# Altium schematic sheet SH4 - MICROCONTROLLER.SchDoc
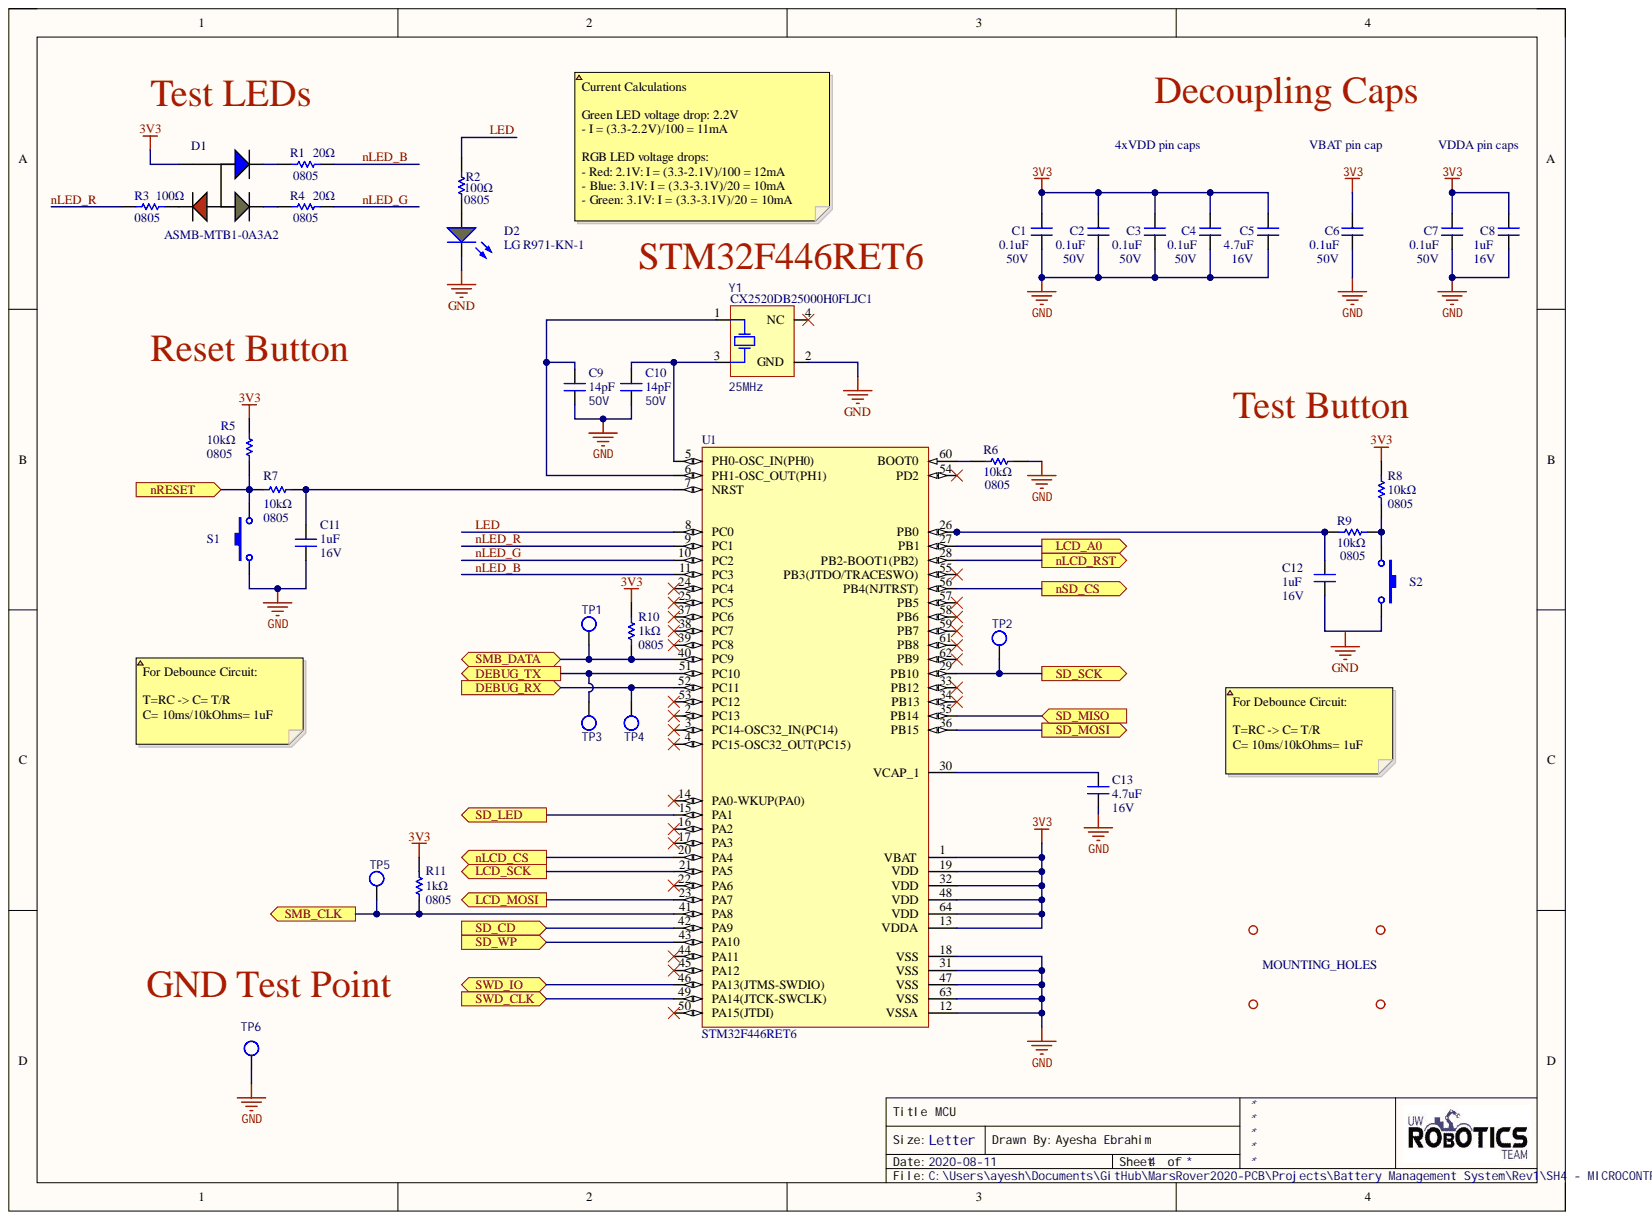
[diagram p0: SH4 - MICROCONTROLLER.SchDoc]

## Parts
C1  value 0.1uF  Voltage Rating: 50V  [CAPC2012X140N]  (hidden comment: CAP_0.1uF_50V_0805)
C2  value 0.1uF  Voltage Rating: 50V  [CAPC2012X140N]  (hidden comment: CAP_0.1uF_50V_0805)
C3  value 0.1uF  Voltage Rating: 50V  [CAPC2012X140N]  (hidden comment: CAP_0.1uF_50V_0805)
C4  value 0.1uF  Voltage Rating: 50V  [CAPC2012X140N]  (hidden comment: CAP_0.1uF_50V_0805)
C5  value 4.7uF  Capacitance: 4.7uF  Voltage Rating: 16V  [CAPC2012X140N]  (hidden comment: CAP_4.7uF_16V_0805)
C6  value 0.1uF  Voltage Rating: 50V  [CAPC2012X140N]  (hidden comment: CAP_0.1uF_50V_0805)
C7  value 0.1uF  Voltage Rating: 50V  [CAPC2012X140N]  (hidden comment: CAP_0.1uF_50V_0805)
C8  value 1uF  Capacitance: 1uF  Voltage Rating: 16V  [CAPC2012X140N]  (hidden comment: CAP_1uF_16V_0805)
C9  value 14pF  Capacitance: 14pF  Voltage Rating: 50V  [CAPC0603(1608)55_N]  (hidden comment: CL10C140JB8NNNC)
C10  value 14pF  Capacitance: 14pF  Voltage Rating: 50V  [CAPC0603(1608)55_N]  (hidden comment: CL10C140JB8NNNC)
C11  value 1uF  Capacitance: 1uF  Case Code (Imperial): 0805  Voltage Rating: 16V  [CAPC2012X140N]  (hidden comment: CAP_1uF_16V_0805)
C12  value 1uF  Capacitance: 1uF  Case Code (Imperial): 0805  Voltage Rating: 16V  [CAPC2012X140N]  (hidden comment: CAP_1uF_16V_0805)
C13  value 4.7uF  Capacitance: 4.7uF  Voltage Rating: 16V  [CAPC2012X140N]  (hidden comment: CAP_4.7uF_16V_0805)
D1  Manufacturer Part Number 1: ASMB-MTB1-0A3A2  [LED_RGB]  (hidden comment: LED_RGB)
D2  DIO_LED_G  [LED_0805_LINE]  (value not drawn)
MH1  MOUNTING_HOLES  [MOUNTING_HOLE]
MH2  MOUNTING_HOLES  [MOUNTING_HOLE]
MH3  MOUNTING_HOLES  [MOUNTING_HOLE]
MH4  MOUNTING_HOLES  [MOUNTING_HOLE]
R1  value 20Ω  Case Code (Imperial): 0805  [RESC2012X60N]  (hidden comment: RES_20Ω_0805)
R2  value 100Ω  Case Code (Imperial): 0805  [RESC2012X60N]  (hidden comment: RES_100Ω_0805)
R3  value 100Ω  Case Code (Imperial): 0805  [RESC2012X60N]  (hidden comment: RES_100Ω_0805)
R4  value 20Ω  Case Code (Imperial): 0805  [RESC2012X60N]  (hidden comment: RES_20Ω_0805)
R5  value 10kΩ  Case Code (Imperial): 0805  [RESC2012X60N]  (hidden comment: RES_10kΩ_0805)
R6  value 10kΩ  Case Code (Imperial): 0805  [RESC2012X60N]  (hidden comment: RES_10kΩ_0805)
R7  value 10kΩ  [RESC2012X60N]  (hidden comment: RES_10kΩ_0805)
R8  value 10kΩ  Case Code (Imperial): 0805  [RESC2012X60N]  (hidden comment: RES_10kΩ_0805)
R9  value 10kΩ  [RESC2012X60N]  (hidden comment: RES_10kΩ_0805)
R10  value 1kΩ  Case Code (Imperial): 0805  [RESC2012X60N]  (hidden comment: RES_1kΩ_0805)
R11  value 1kΩ  Case Code (Imperial): 0805  [RESC2012X60N]  (hidden comment: RES_1kΩ_0805)
S1  SW_TACTILE  [B3U-1000P]  (value not drawn)
S2  SW_TACTILE  [B3U-1000P]  (value not drawn)
TP1  TESTPOINT_LOOP  [TESTPOINT_LOOP]  (value not drawn)
TP2  TESTPOINT_LOOP  [TESTPOINT_LOOP]  (value not drawn)
TP3  TESTPOINT_LOOP  [TESTPOINT_LOOP]  (value not drawn)
TP4  TESTPOINT_LOOP  [TESTPOINT_LOOP]  (value not drawn)
TP5  TESTPOINT_LOOP  [TESTPOINT_LOOP]  (value not drawn)
TP6  TESTPOINT_LOOP  [TESTPOINT_LOOP]  (value not drawn)
U1  STM32F446RET6  [STM-LQFP64_L]
Y1  Frequency: 25MHz  Manufacturer Part Number 1: CX2520DB25000H0FLJC1  [SOIC254P1016X440-4N]  (hidden comment: CRYSTAL_25MHZ)

## Ports
DEBUG_RX, DEBUG_TX, LCD_A0, LCD_MOSI, LCD_SCK, nLCD_CS, nLCD_RST, nRESET, nSD_CS, SD_CD, SD_LED, SD_MISO, SD_MOSI, SD_SCK, SD_WP, SMB_CLK, SMB_DATA, SWD_CLK, SWD_IO

## Nets
3V3: C1.2 C2.2 C3.2 C4.2 C5.2 C6.2 C7.2 C8.2 D1.4 R5.2 R8.2 R10.2 R11.2 U1.1(VBAT) U1.13(VDDA) U1.19(VDD) U1.32(VDD) U1.48(VDD) U1.64(VDD)
GND: C1.1 C2.1 C3.1 C4.1 C5.1 C6.1 C7.1 C8.1 C9.1 C10.1 C11.1 C13.1 D2.2 R6.2 S1.1 TP6.1 U1.12(VSSA) U1.18(VSS) U1.31(VSS) U1.47(VSS) U1.63(VSS) Y1.2(GND)
DEBUG_RX: TP4.1 U1.52(PC11) ; port DEBUG_RX
DEBUG_TX: TP3.1 U1.51(PC10) ; port DEBUG_TX
LCD_A0: U1.27(PB1) ; port LCD_A0
LCD_MOSI: U1.23(PA7) ; port LCD_MOSI
LCD_SCK: U1.21(PA5) ; port LCD_SCK
LED: R2.2 U1.8(PC0)
nLCD_CS: U1.20(PA4) ; port nLCD_CS
nLCD_RST: U1.28(PB2-BOOT1(PB2)) ; port nLCD_RST
nLED_B: R1.2 U1.11(PC3)
nLED_G: R4.2 U1.10(PC2)
nLED_R: R3.1 U1.9(PC1)
nRESET: R5.1 R7.1 S1.2 ; port nRESET
nSD_CS: U1.56(PB4(NJTRST)) ; port nSD_CS
SD_CD: U1.42(PA9) ; port SD_CD
SD_LED: U1.15(PA1) ; port SD_LED
SD_MISO: U1.35(PB14) ; port SD_MISO
SD_MOSI: U1.36(PB15) ; port SD_MOSI
SD_SCK: TP2.1 U1.29(PB10) ; port SD_SCK
SD_WP: U1.43(PA10) ; port SD_WP
SMB_CLK: R11.1 TP5.1 U1.41(PA8) ; port SMB_CLK
SMB_DATA: R10.1 TP1.1 U1.40(PC9) ; port SMB_DATA
SWD_CLK: U1.49(PA14(JTCK-SWCLK)) ; port SWD_CLK
SWD_IO: U1.46(PA13(JTMS-SWDIO)) ; port SWD_IO
NetC9_2: C9.2 U1.6(PH1-OSC_OUT(PH1)) Y1.1
NetC10_2: C10.2 U1.5(PH0-OSC_IN(PH0)) Y1.3
NetC11_2: C11.2 R7.2 U1.7(NRST)
NetC12_1: C12.1 S2.2
NetC12_2: C12.2 R9.1 U1.26(PB0)
NetC13_2: C13.2 U1.30(VCAP_1)
NetD1_1: D1.1 R3.2
NetD1_2: D1.2 R4.1
NetD1_3: D1.3 R1.1
NetD2_1: D2.1 R2.1
NetR6_1: R6.1 U1.60(BOOT0)
NetR8_1: R8.1 R9.2 S2.1

Not connected: U1 2(PC13) 3(PC14-OSC32_IN(PC14)) 4(PC15-OSC32_OUT(PC15)) 14(PA0-WKUP(PA0)) 16(PA2) 17(PA3) 22(PA6) 24(PC4) 25(PC5) 33(PB12) 34(PB13) 37(PC6) 38(PC7) 39(PC8) 44(PA11) 45(PA12) 50(PA15(JTDI)) 53(PC12) 54(PD2) 55(PB3(JTDO/TRACESWO)) 57(PB5) 58(PB6) 59(PB7) 61(PB8) 62(PB9); Y1 4(NC)

## Library data of the parts
C1-C4 C6 C7 = CAP_0.1uF_50V_0805: CAP CER 0.1UF 50V X7R 0805; Manufacturer 1: Murata Electronics; Manufacturer Part Number 1: GCD21BR71H104KA01L; Capacitance: 100nF; Tolerance: 10%; Case Code (Imperial): 0805; Case Code (Metric): 2012; Case/Package: 0805; Component Kind: Standard; ComponentLink1Description: https://datasheet.ciiva.com/29537/c03e-29537701.pdf?src-supplier=Digi-Key; ComponentLink1URL: https://datasheet.ciiva.com/29537/c03e-29537701.pdf?src-supplier=Digi-Key; Depth: 1.25mm; Dielectric: X7R; Height: 1.25mm; Length: 2mm; Max Operating Temperature: 125°C; Min Operating Temperature: -55°C; Mount: Surface Mount; Ratings: AEC-Q200; Supplier 1: Digi-Key; Supplier Part Number 1: 490-11955-1-ND; Termination: SMD/SMT; Thickness: 0.049inch; Width: 0.049inch; Library Name: UWRT_Schematic_Library_2020.SchLib
C5 C13 = CAP_4.7uF_16V_0805: CAP CER 4.7UF 16V X5R 0805; Manufacturer 1: Samsung Electro-Mechanics; Manufacturer Part Number 1: CL21A475KOFNNNE; Tolerance: 10%; Case Code (Imperial): 0805; Case Code (Metric): 2012; Case/Package: 0805; Component Kind: Standard; Dielectric: X5R; Max Operating Temperature: 85°C; Min Operating Temperature: -55°C; Mount: Surface Mount; Supplier 1: Digi-Key; Supplier Part Number 1: 1276-1065-1-ND; Termination: SMD/SMT; Library Name: UWRT_Schematic_Library_2020.SchLib
C8 C11 C12 = CAP_1uF_16V_0805: CAP CER 1UF 16V X7R 0805; Manufacturer 1: Yageo; Manufacturer Part Number 1: CC0805KKX7R7BB105; Tolerance: 10%; Case Code (Metric): 2012; Case/Package: 0805; Component Kind: Standard; Dielectric: X7R; Max Operating Temperature: 125°C; Min Operating Temperature: -55°C; Mount: Surface Mount; Supplier 1: Digi-Key; Supplier Part Number 1: 311-1365-1-ND; Termination: SMD/SMT; Library Name: UWRT_Schematic_Library_2020.SchLib
  C8: Case Code (Imperial): 0805
C9 C10 = CL10C140JB8NNNC: Cap Ceramic 14pF 50V C0G 5% SMD 0603 125C Cardboard T/R; Manufacturer 1: Samsung; Case Code (Imperial): 0603; Case Code (Metric): 1608; Case/Package: 0603; Component Kind: Standard; ComponentLink1Description: https://datasheet.ciiva.com/25782/cl10c140jb8nnnc-25782947.pdf?src-supplier=Digi-Key; ComponentLink1URL: https://datasheet.ciiva.com/25782/cl10c140jb8nnnc-25782947.pdf?src-supplier=Digi-Key; Depth: 0.8mm; Dielectric: C0G; Height: 0.8mm; Length: 1.6mm; Manufacturer Part Number 1: CL10C140JB8NNNC; Max Operating Temperature: 125°C; Min Operating Temperature: -55°C; Mount: Surface Mount; Package Quantity: 1; Packaging: Tape and Reel; Pricing 1: 1=0.10000, 10=0.06700, 100=0.02980, 500=0.02130, 1000=0.01673 (USD); Radiation Hardening: No; RoHS: Yes; Stock 1: 187088; Supplier 1: Digi-Key; Supplier Part Number 1: 1276-2187-1-ND; Thickness: 0.035inch; Tolerance: 5%; Voltage Rating (DC): 50V; Width: 0.031inch; Library Name: UWRT_Schematic_Library_2020.SchLib
D1 = LED_RGB: LED RGB DIFFUSED 4PLCC SMD; Manufacturer 1: Broadcom; Color: Blue,Green,Red; Dominant Wavelength: 470nm; Forward Voltage: 3.1V; Lens Color: White; Lens Style: Round; Luminous Intensity: 350mcd; Test Current: 20mA; Viewing Angle: 115°; Case/Package: PLCC; Component Kind: Standard; ComponentLink1Description: http://www.farnell.com/datasheets/2111106.pdf?src-supplier=Farnell; ComponentLink1URL: http://www.farnell.com/datasheets/2111106.pdf?src-supplier=Farnell; ComponentLink2Description: https://docs.broadcom.com/docs/AV02-4194EN?src-supplier=Digi-Key; ComponentLink2URL: https://docs.broadcom.com/docs/AV02-4194EN?src-supplier=Digi-Key; ComponentLink3Description: http://www.farnell.com/datasheets/1801564.pdf?src-supplier=Element14; ComponentLink3URL: http://www.farnell.com/datasheets/1801564.pdf?src-supplier=Element14; ComponentLink4Description: http://www.farnell.com/datasheets/2111106.pdf?src-supplier=Newark; ComponentLink4URL: http://www.farnell.com/datasheets/2111106.pdf?src-supplier=Newark; Height: 1.9mm; Length: 3.2mm; Lens Transparency: Diffused; Mount: Surface Mount; Number of Pins: 4; Packaging: Cut Tape; Pricing 1: 1=0.60000, 10=0.47100, 100=0.30210, 500=0.25980, 1000=0.20059 (USD); REACH SVHC: No SVHC; RoHS: Yes; Stock 1: 142531; Supplier 1: Digi-Key; Supplier Part Number 1: 516-3280-1-ND; Width: 2.8mm; Library Name: UWRT_Schematic_Library_2020.SchLib
D2 = DIO_LED_G: LED GREEN DIFFUSED 0805 SMD; Manufacturer 1: OSRAM; Manufacturer Part Number 1: LG R971-KN-1; Forward Voltage Drop: 2.2V; Component Kind: Standard; Mount: Surface Mount; Package: 0805 (2012 Metric); Simulation: LED1; Supplier 1: Digi-Key; Supplier Part Number 1: 475-1410-1-ND; Library Name: UWRT_Schematic_Library_2020.SchLib
MH1-MH4 = MOUNTING_HOLES: 6cm x 6cm; Component Kind: Standard; Library Name: UWRT_Schematic_Library_2020.SchLib
R1 R4 = RES_20Ω_0805: RES SMD 20 OHM 1% 1/8W 0805; Manufacturer 1: Yageo; Manufacturer Part Number 1: RC0805FR-0720RL; Power Rating: 125mW; Resistance: 20; Case Code (Metric): 2012; Component Kind: Standard; Max Operating Temperature: 155°C; Min Operating Temperature: -55°C; Supplier 1: Digi-Key; Supplier Part Number 1: 311-20.0CRCT-ND; Termination: SMD/SMT; Tolerance: 1%; Library Name: UWRT_Schematic_Library_2020.SchLib
R2 R3 = RES_100Ω_0805: RES SMD 100 OHM 1% 1/8W 0805; Manufacturer 1: Yageo; Manufacturer Part Number 1: RC0805FR-07100RL; Power Rating: 125mW; Case Code (Metric): 2012; Component Kind: Standard; Mount: Surface Mount; Supplier 1: Digi-Key; Supplier Part Number 1: 311-100CRCT-ND; Library Name: UWRT_Schematic_Library_2020.SchLib
R5-R9 = RES_10kΩ_0805: RES SMD 10K OHM 1% 1/8W 0805; Manufacturer 1: Yageo; Manufacturer Part Number 1: RC0805FR-0710KL; Power Rating: 125mW; Case Code (Metric): 2012; Component Kind: Standard; Max Operating Temperature: 155°C; Min Operating Temperature: -55°C; Resistance: 10K; Supplier 1: Digi-Key; Supplier Part Number 1: 311-10.0KCRCT-ND; Termination: SMD/SMT; Tolerance: 1%; Library Name: UWRT_Schematic_Library_2020.SchLib
  R7 R9: Case Code (Imperial): 0805
R10 R11 = RES_1kΩ_0805: RES SMD 1K OHM 1% 1/8W 0805; Manufacturer 1: Yageo; Manufacturer Part Number 1: RC0805FR-071KL; Power Rating: 125mW; Case Code (Metric): 2012; Component Kind: Standard; Max Operating Temperature: 155°C; Min Operating Temperature: -55°C; Resistance: 1K; Supplier 1: Digi-Key; Supplier Part Number 1: 311-1.00KCRCT-ND; Termination: SMD/SMT; Tolerance: 1%; Library Name: UWRT_Schematic_Library_2020.SchLib
S1 S2 = SW_TACTILE: Tactile Switch (Grey); Manufacturer 1: Omron Electronics Inc-EMC Div; Component Kind: Standard; Manufacturer Part Number 1: B3U-1000P; Mount: Surface Mount; Supplier 1: Digi-Key; Supplier Part Number 1: SW1020CT-ND; Library Name: UWRT_Schematic_Library_2020.SchLib
TP1-TP6 = TESTPOINT_LOOP: Loop testpoint through-hole pad; Manufacturer 1: Keystone Electronics; Manufacturer Part Number 1: 5002; Component Kind: Standard; Mount: Through Hole; Supplier 1: Digi-Key; Supplier Part Number 1: 36-5002-ND; Library Name: UWRT_Schematic_Library_2020.SchLib
U1 = MCU_STM32F446RET6: ARM Cortex-M4 32-bit MCU+FPU, 512 KB Flash, 128 KB Internal RAM, 50 I/Os, 64-Pin LQFP, -40 to 85 degC, Tray; D/A Converter: 2; FLASH Size (Prog) (kB): 512; I/Os (High Current): 50; Internal RAM Size (kB): 128; Operating Frequency(F) (Processor speed) (MHz): 180; Supply Voltage(Vcc) min (V): 1.7; Component Kind: Standard; ComponentLink1Description: Manufacturer URL; ComponentLink1URL: http://www.st.com/; ComponentLink2Description: Datasheet; ComponentLink2URL: http://www.st.com/st-web-ui/static/active/en/resource/technical/document/datasheet/DM00141306.pdf; Core: ARM Cortex-M4; DatasheetVersion: Rev. 6; Mounting Technology: Surface Mount; Package Description: LQFP64; Package Reference: 64-Pin Low-profile Quad Flat Package, Body 10 x 10 mm, Pitch 0.5 mm; Packing: Tray; Supplier 1: Digi-Key; Supplier Part Number 1: 497-15376-ND; Library Name: UWRT_Schematic_Library_2020.SchLib
Y1 = CRYSTAL_25MHZ: CRYSTAL 25.0000MHZ 12PF SMD; Manufacturer 1: Kyocera AVX; ESR (Equivalent Series Resistance): 80R; Frequency Stability: 15ppm; Frequency Tolerance: 10ppm; Case/Package: SMD/SMT; Component Kind: Standard; ComponentLink1Description: https://media.digikey.com/pdf/Data%20Sheets/AVX%20PDFs/CX2520DB_USY1M-H1-16429-00_Spec.pdf?src-supplier=Digi-Key; ComponentLink1URL: https://media.digikey.com/pdf/Data%20Sheets/AVX%20PDFs/CX2520DB_USY1M-H1-16429-00_Spec.pdf?src-supplier=Digi-Key; Height: 0.022inch; Length: 0.098inch; Load Capacitance: 12pF; Max Operating Temperature: 85°C; Min Operating Temperature: -30°C; Mount: Surface Mount; Packaging: Tape and Reel; Pricing 1: 1=0.72000, 10=0.63300, 50=0.59120, 100=0.52250, 500=0.49500, 1000=0.41250 (USD); Ratings: AEC-Q200; RoHS: Yes; Stock 1: 11741; Supplier 1: Digi-Key; Supplier Part Number 1: 1253-1724-1-ND; Width: 0.079inch; Library Name: UWRT_Schematic_Library_2020.SchLib
